# Altium schematic sheet Steering_Wheel_Board (1).SchDoc("Steering_Wheel_Board (1)")
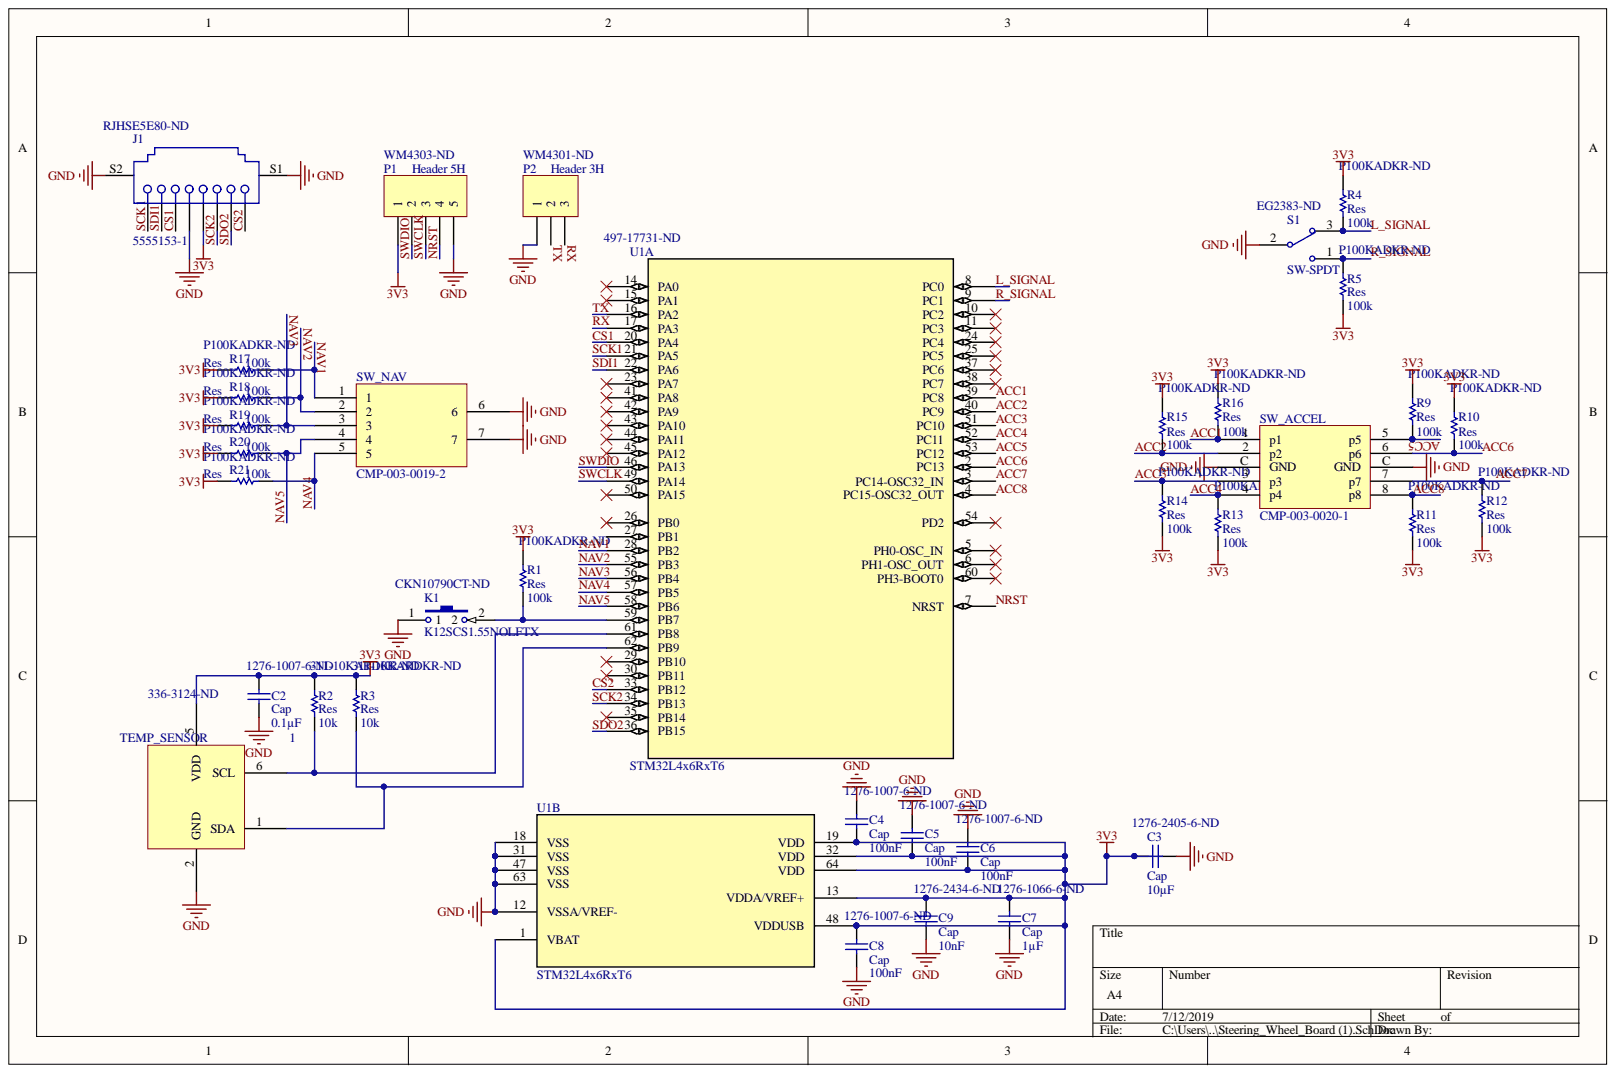
[diagram p0: Steering_Wheel_Board ]

## Parts
1  TEMP_SENSOR  Supplier Part Number 1: 336-3124-ND  [Temp_Sensor]
C2  Cap  value 0.1µF  Supplier Part Number 1: 1276-1007-6-ND  [C0805]
C3  Cap  value 10µF  Supplier Part Number 1: 1276-2405-6-ND  [C0805]
C4  Cap  value 100nF  Supplier Part Number 1: 1276-1007-6-ND  [C0805]
C5  Cap  value 100nF  Supplier Part Number 1: 1276-1007-6-ND  [C0805]
C6  Cap  value 100nF  Supplier Part Number 1: 1276-1007-6-ND  [C0805]
C7  Cap  value 1µF  Supplier Part Number 1: 1276-1066-6-ND  [C0805]
C8  Cap  value 100nF  Supplier Part Number 1: 1276-1007-6-ND  [C0805]
C9  Cap  value 10nF  Supplier Part Number 1: 1276-2434-6-ND  [C0805]
J1  5555153-1  Supplier Part Number 1: RJHSE5E80-ND  [RJ45 - CHN + DIGIKEY]
K1  K12SCS1.55NOLFTX  Supplier Part Number 1: CKN10790CT-ND  [Pushbutton]
P1  Header 5H  Supplier Part Number 1: WM4303-ND  [HDR1X5H]
P2  Header 3H  Supplier Part Number 1: WM4301-ND  [HDR1X3H]
R1  Res  value 100k  Supplier Part Number 1: P100KADKR-ND  [C0805]
R2  Res  value 10k  Supplier Part Number 1: 311-10KARDKR-ND  [C0805]
R3  Res  value 10k  Supplier Part Number 1: 311-10KARDKR-ND  [C0805]
R4  Res  value 100k  Supplier Part Number 1: P100KADKR-ND  [C0805]
R5  Res  value 100k  Supplier Part Number 1: P100KADKR-ND  [C0805]
R9  Res  value 100k  Supplier Part Number 1: P100KADKR-ND  [C0805]
R10  Res  value 100k  Supplier Part Number 1: P100KADKR-ND  [C0805]
R11  Res  value 100k  Supplier Part Number 1: P100KADKR-ND  [C0805]
R12  Res  value 100k  Supplier Part Number 1: P100KADKR-ND  [C0805]
R13  Res  value 100k  Supplier Part Number 1: P100KADKR-ND  [C0805]
R14  Res  value 100k  Supplier Part Number 1: P100KADKR-ND  [C0805]
R15  Res  value 100k  Supplier Part Number 1: P100KADKR-ND  [C0805]
R16  Res  value 100k  Supplier Part Number 1: P100KADKR-ND  [C0805]
R17  Res  value 100k  Supplier Part Number 1: P100KADKR-ND  [C0805]
R18  Res  value 100k  Supplier Part Number 1: P100KADKR-ND  [C0805]
R19  Res  value 100k  Supplier Part Number 1: P100KADKR-ND  [C0805]
R20  Res  value 100k  Supplier Part Number 1: P100KADKR-ND  [C0805]
R21  Res  Supplier Part Number 1: P100KADKR-ND  [C0805]
S1  SW-SPDT  Supplier Part Number 1: EG2383-ND  [3POSSPDT]
SW_ACCEL  CMP-003-0020-1  [EAW0J-B24-CE0128L]
SW_NAV  CMP-003-0019-2  [TSWB3NCB222LFS]
U1  STM32L4x6RxT6  Supplier Part Number 1: 497-17731-ND  [LQFP64-10x10mm]  (parts A,B)

## Nets
3V3: 1.5(VDD) C2.2 C3.2 C4.2 C5.2 C6.2 C7.2 C8.2 C9.2 J1.5 P1.1 R1.1 R2.1 R3.1 R4.1 R5.2 R9.1 R10.1 R11.2 R12.2 R13.2 R14.2 R15.1 R16.1 R17.1 R18.1 R19.1 R20.1 R21.1 U1.1(VBAT) U1.13(VDDA/VREF+) U1.19(VDD) U1.32(VDD) U1.48(VDDUSB) U1.64(VDD)
GND: 1.2(GND) C2.1 C3.1 C4.1 C5.1 C6.1 C7.1 C8.1 C9.1 J1.4 J1.S1 J1.S2 K1.1 P1.5 P2.1 S1.2 SW_ACCEL.C(GND) SW_NAV.6 SW_NAV.7 U1.12(VSSA/VREF-) U1.18(VSS) U1.31(VSS) U1.47(VSS) U1.63(VSS)
ACC1: R16.2 SW_ACCEL.1(p1) U1.39(PC8)
ACC2: R15.2 SW_ACCEL.2(p2) U1.40(PC9)
ACC3: R14.1 SW_ACCEL.3(p3) U1.51(PC10)
ACC4: R13.1 SW_ACCEL.4(p4) U1.52(PC11)
ACC5: R9.2 SW_ACCEL.5(p5) U1.53(PC12)
ACC6: R10.2 SW_ACCEL.6(p6) U1.2(PC13)
ACC7: R12.1 SW_ACCEL.7(p7) U1.3(PC14-OSC32_IN)
ACC8: R11.1 SW_ACCEL.8(p8) U1.4(PC15-OSC32_OUT)
CS1: J1.3 U1.20(PA4)
CS2: J1.8 U1.33(PB12)
L_SIGNAL: R4.2 S1.3 U1.8(PC0)
NAV1: R17.2 SW_NAV.1 U1.28(PB2)
NAV2: R18.2 SW_NAV.2 U1.55(PB3)
NAV3: R19.2 SW_NAV.3 U1.56(PB4)
NAV4: R21.2 SW_NAV.5 U1.57(PB5)
NAV5: R20.2 SW_NAV.4 U1.58(PB6)
NRST: P1.4 U1.7(NRST)
R_SIGNAL: R5.1 S1.1 U1.9(PC1)
RX: P2.3 U1.17(PA3)
SCK1: J1.1 U1.21(PA5)
SCK2: J1.6 U1.34(PB13)
SDI1: J1.2 U1.22(PA6)
SDO2: J1.7 U1.36(PB15)
SWCLK: P1.3 U1.49(PA14)
SWDIO: P1.2 U1.46(PA13)
TX: P2.2 U1.16(PA2)
Net1_1: 1.1(SDA) R3.2 U1.62(PB9)
Net1_6: 1.6(SCL) R2.2 U1.61(PB8)
NetK1_2: K1.2 R1.2 U1.59(PB7)

Not connected: U1 5(PH0-OSC_IN) 6(PH1-OSC_OUT) 10(PC2) 11(PC3) 14(PA0) 15(PA1) 23(PA7) 24(PC4) 25(PC5) 26(PB0) 27(PB1) 29(PB10) 30(PB11) 35(PB14) 37(PC6) 38(PC7) 41(PA8) 42(PA9) 43(PA10) 44(PA11) 45(PA12) 50(PA15) 54(PD2) 60(PH3-BOOT0)

## Library data of the parts
1 = Temp_Sensor: Si7000-A20 Temperature and Humidity Sensor; Supplier 1: Digi-Key; Component Kind: Standard; Library Name: Steering_Wheel.SchLib
C2-C9 = Cap: Capacitor; Supplier 1: Digi-Key; Simulation: CAP; Signal Integrity: Cap; Published: 8-Jun-2000; PackageReference: RAD-0.3; LatestRevisionNote: Re-released for DXP Platform.; LatestRevisionDate: 17-Jul-2002; Component Kind: Standard; Publisher: Altium Limited; Library Name: blueskyLib.SchLib
J1 = CMP-2000-05640-1: RJ45 Connector, Pitch 1.27 mm, 8 Position, Height 13.08 mm, Tail Length 3.81 mm, -40 to 85 degC, RoHS, Tray; Manufacturer: TE Connectivity; Tab Direction: Down; Shielding: Shielded; Ratings: Cat3; Other Names: A31438; Number of Positions/Contacts: 8p8c(RJ45,Ethernet); Number of Ports: 1; LED Color: DoesNotContainLED; Dynamic Catalog: ModularJacks; Connector Type: Jack; Catalog Drawings: JackSideEntryRightAngle    JackSideEntryRightAngleTop; 3D Model: 5555153-1.pdf; Termination: Solder; Supplier 1: Digi-Key; Standard Package: 136; RoHS Information: 5555153-1StatementofCompliance; Packaging: Tray; Package Reference: 5555153-1; Package Description: RJ45 Connector, Pitch 1.27 mm, 8 Position, Height 13.08 mm, Tail Length 3.81 mm; Orientation: 90°Angle(Right); Operating Temperature: -40°C~85°C; Number of Rows: 1; Mounting Type: ThroughHole; Mounting Technology: ThroughHole; Manufacturer URL: http://www.te.com/; Housing Material: PolybutyleneTerephthalate(PBT),Polyester; Features: BoardLock,PanelStops; Family: ModularConnectors-Jacks; Datasheet Version: Rev. D2, 05/2016; Datasheet URL: http://www.te.com/commerce/DocumentDelivery/DDEController?Action=srchrtrv&DocNm=5555153&DocType=Customer+Drawing&DocLang=English&DocFormat=pdf&PartCntxt=5555153-1; Contact Material: PhosphorBronze; Contact Finish Thickness: 50µin(1.27µm); Contact Finish: Gold; Component Kind: Standard; Category: Connectors,Interconnects; Library Name: Steering_Wheel.SchLib
K1 = Pushbutton: Supplier 1: Digi-Key; Description: Pushbutton; Component Kind: Standard; Library Name: gloria_library.SchLib
P1 = Header 5H: Header, 5-Pin, Right Angle; Supplier 1: Digi-Key; Signal Integrity: Connector; Library Name: Miscellaneous Connectors.IntLib; LatestRevisionNote: Re-released for DXP Platform.; LatestRevisionDate: 17-Jul-2002; Component Kind: Standard; Publisher: Altium Limited
P2 = Header 3H: Header, 3-Pin, Right Angle; Supplier 1: Digi-Key; Signal Integrity: Connector; Library Name: Miscellaneous Connectors.IntLib; LatestRevisionNote: Re-released for DXP Platform.; LatestRevisionDate: 17-Jul-2002; Component Kind: Standard; Publisher: Altium Limited
R1 R4 R5 R9-R20 = Res: Rectangular Thick Film Chip Resistor, 10 Ohm to 1M Ohm Range, 0.1% and 0.5% Tolerance, 0805 Size, 0.1 W; Note: If flow soldering, adjust pad width of PCB Footprint to 0.7 - 0.8 times the width of the resistor.; Supplier 1: Digi-Key; Simulation: RESISTOR; Signal Integrity: Res; Published: 17-Dec-2003; PackageReference: ERA-0805; PackageDocument: Mar-2003; LatestRevisionNote: Silkscreen size reduced.; LatestRevisionDate: 19-Mar-2004; ComponentLink2URL: http://www.panasonic.com/industrial/components/pdf/AOA0000CE8.pdf; ComponentLink2Description: Datasheet; ComponentLink1URL: http://www.panasonic.com/industrial/components/resistive_products.htm; ComponentLink1Description: Manufacturer Link; Component Kind: Standard; Code_IPC: 2012 [0805]; Publisher: Altium Limited; Library Name: blueskyLib.SchLib
R2 R3 = Res: Note: If flow soldering, adjust pad width of PCB Footprint to 0.7 - 0.8 times the width of the resistor.; Supplier 1: Digi-Key; Simulation: RESISTOR; Signal Integrity: Res; Published: 17-Dec-2003; PackageReference: ERA-0805; PackageDocument: Mar-2003; LatestRevisionNote: Silkscreen size reduced.; LatestRevisionDate: 19-Mar-2004; Component Kind: Standard; Code_IPC: 2012 [0805]; Publisher: Altium Limited; Library Name: blueskyLib.SchLib
R21 = Res: Rectangular Thick Film Chip Resistor, 10 Ohm to 1M Ohm Range, 0.1% and 0.5% Tolerance, 0805 Size, 0.1 W; Value: 100k; Note: If flow soldering, adjust pad width of PCB Footprint to 0.7 - 0.8 times the width of the resistor.; Supplier 1: Digi-Key; Simulation: RESISTOR; Signal Integrity: Res; Published: 17-Dec-2003; PackageReference: ERA-0805; PackageDocument: Mar-2003; LatestRevisionNote: Silkscreen size reduced.; LatestRevisionDate: 19-Mar-2004; ComponentLink2URL: http://www.panasonic.com/industrial/components/pdf/AOA0000CE8.pdf; ComponentLink2Description: Datasheet; ComponentLink1URL: http://www.panasonic.com/industrial/components/resistive_products.htm; ComponentLink1Description: Manufacturer Link; Component Kind: Standard; Code_IPC: 2012 [0805]; Publisher: Altium Limited; Library Name: blueskyLib.SchLib
S1 = SW-SPDT: SPDT Subminiature Toggle Switch, Right Angle Mounting, Vertical Actuation; Supplier 1: Digi-Key; Published: 24-Mar-1999; PackageReference: TL36WW15050; PackageDescription: Switch; 4 Leads; Library Name: Miscellaneous Devices.IntLib; LatestRevisionNote: Schematic revised, PCB Footprint replaced.; LatestRevisionDate: 17-Dec-2003; DatasheetVersion: 05-Dec-2000; ComponentLink1URL: http://www.apem.com; ComponentLink1Description: Manufacturer Link; Component Kind: Standard; Publisher: Altium Limited
SW_ACCEL = CMP-003-0020-1: Rotary Encoder - 8 pins; Library Name: <Company> Vault; Component Name: EAW0J-B24-CE0128L; Component Kind: Standard; Source: steering wheel.SchLib
SW_NAV = CMP-003-0019-2: Navigational Switch; Library Name: <Company> Vault; Component Name: TSWB3NCB222LFS; Component Kind: Standard; Source: steering wheel.SchLib
U1 = STM32L4x6RxT6: Imported; Vendor: STMicroelectronics; C: Copyright (C) 2016 Accelerated Designs. All rights reserved; Value: Value; Type: DEV; Supplier 1: Digi-Key; RefDes: RefDes; Manufacturer_Part_Number: stm32l4x6rxt6; Component Kind: Standard; Library Name: Steering_Wheel.SchLib; Copyright: Copyright (C) 2016 Accelerated Designs. All rights reserved
